# Altium schematic sheet KDT849SPDT-003-射频开关.SchDoc
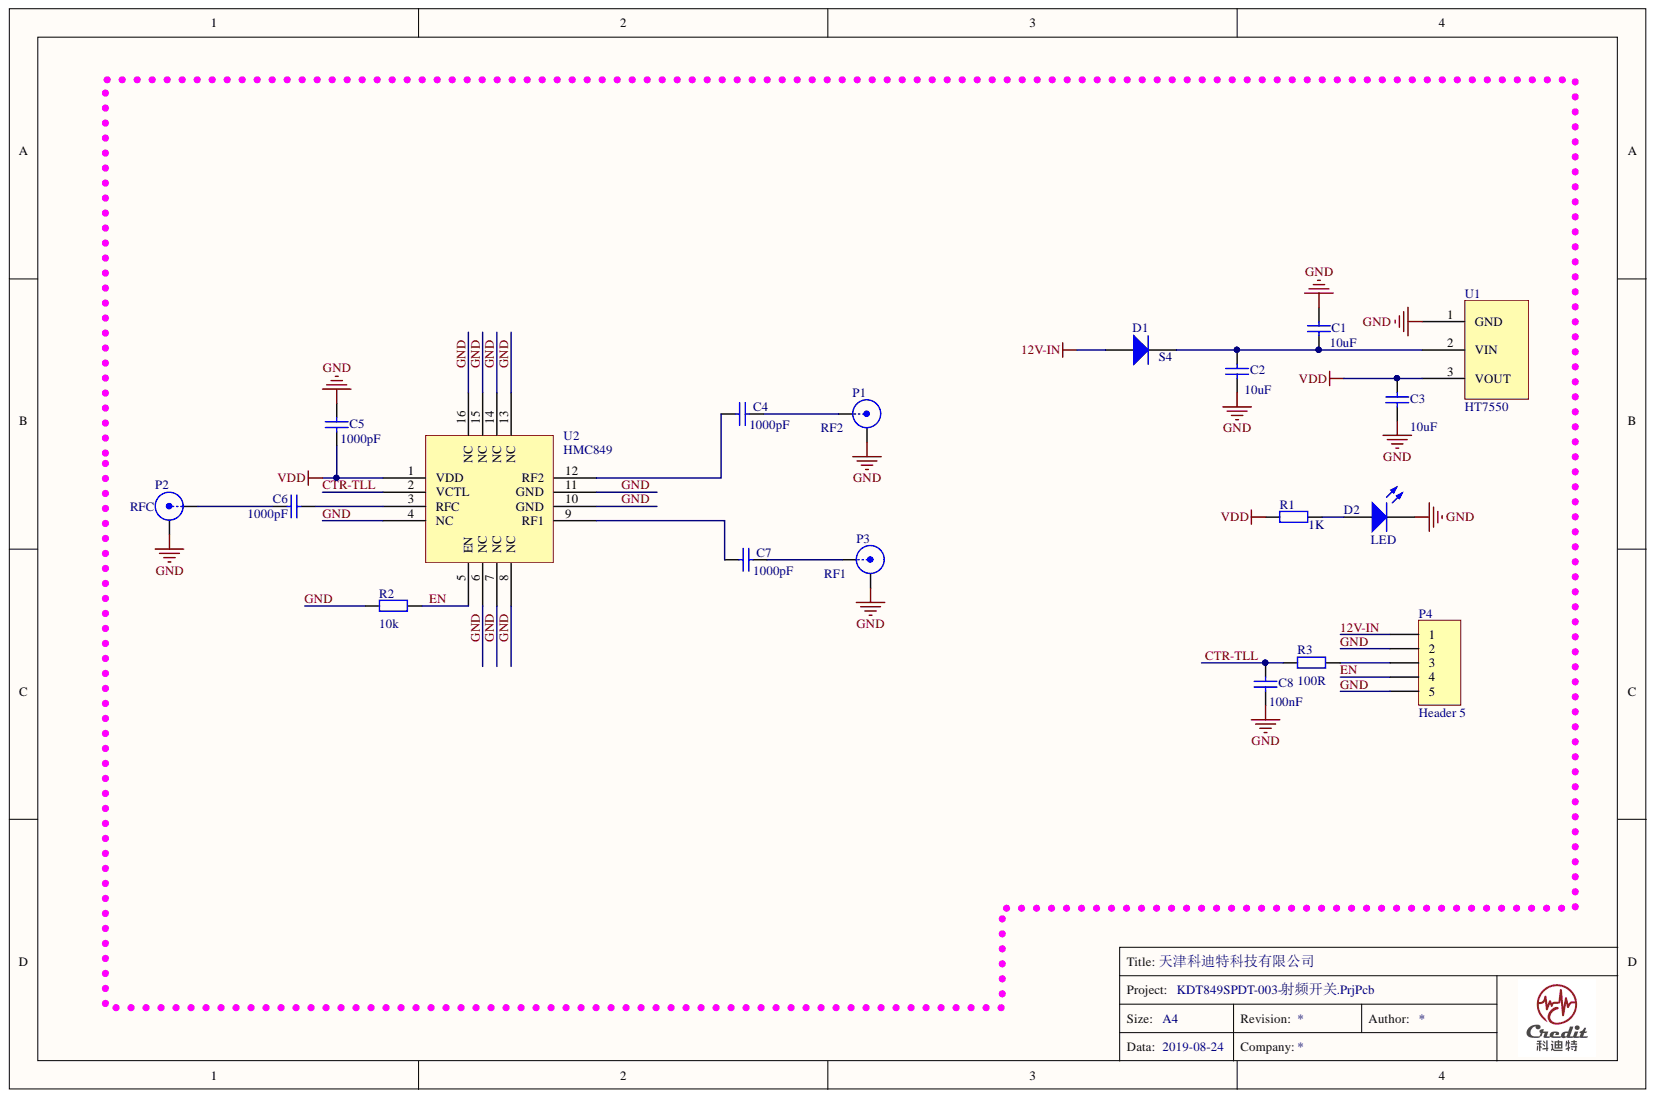
[diagram p0: KDT849SPDT-003-射频开关.SchDoc]

## Parts
C1  value 10uF  [C1206]
C2  value 10uF  [C0805]
C3  value 10uF  [C0805]
C4  value 1000pF  [C0603]
C5  value 1000pF  [C0603]
C6  value 1000pF  [C0603]
C7  value 1000pF  [C0603]
C8  value 100nF  [C0603]
D1  S4  [SOD123]
D2  LED  [LED0805R]
P1  RF2  [SM]
P2  RFC  [SM]
P3  RF1  [SM]
P4  Header 5  [XH2.54-LI-5P]
R1  value 1K  [R0603]
R2  value 10k  [R0603]
R3  value 100R  [R0603]
U1  HT7550  [SOT-89]
U2  HMC849  [QFN16 4x4_N]

## Nets
VDD: C3.1 C5.1 R1.1 U1.3 U2.1(VDD)
12V-IN: D1.1 P4.1
CTR-TLL: C8.1 R3.2 U2.2(VCTL)
EN: P4.4 R2.1 U2.5(EN)
GND: C1.2 C2.2 C3.2 C5.2 C8.2 D2.2 P1.2 P2.2 P3.2 P4.2 P4.5 R2.2 U1.1 U2.4(NC) U2.6(NC) U2.7(NC) U2.8(NC) U2.10(GND) U2.11(GND) U2.13(NC) U2.14(NC) U2.15(NC) U2.16(NC)
NetC1_1: C1.1 C2.1 D1.2 U1.2
NetC4_1: C4.1 P1.1
NetC4_2: C4.2 U2.12(RF2)
NetC6_1: C6.1 P2.1
NetC6_2: C6.2 U2.3(RFC)
NetC7_1: C7.1 P3.1
NetC7_2: C7.2 U2.9(RF1)
NetD2_1: D2.1 R1.2
NetP4_3: P4.3 R3.1

## Library data of the parts
C1-C8 = Cap: Capacitor; Component Kind: Standard; LatestRevisionDate: 17-Jul-2002; LatestRevisionNote: Re-released for DXP Platform.; Library Name: Miscellaneous Devices.IntLib; PackageDescription: Radial Cap, Thru-Hole; 2 Leads; 0.3 in Pin Spacing; PackageReference: RAD-0.3; Published: 8-Jun-2000; Signal Integrity: Cap; Simulation: CAP; Publisher: Altium Limited
D1 = DIODE: High Conductance Fast Diode; Code_JEDEC: DO-204-AH; Component Kind: Standard; ComponentLink1Description: Manufacturer Link; ComponentLink1URL: http://www.fairchildsemi.com/; ComponentLink2Description: Datasheet; ComponentLink2URL: http://www.fairchildsemi.com/ds/1N%2F1N4448.pdf; DatasheetVersion: 1997; LatestRevisionDate: 17-Jul-2002; LatestRevisionNote: Re-released for DXP Platform.; Library Name: Miscellaneous Devices.SchLib; PackageDescription: Diode, Axial; Body 3.8 x 1.9 mm (LxDia. max), Lead Dia. 0.53 mm (max); PackageReference: DO-35; Published: 8-Jun-2000; Publisher: Altium Limited
P1-P3 = BNC: BNC Elbow Connector; Component Kind: Standard; LatestRevisionDate: 17-Jul-2002; LatestRevisionNote: Re-released for DXP Platform.; Library Name: Miscellaneous Devices.SchLib; Signal Integrity: Connector; Publisher: Altium Limited
P4 = Header 5: Header, 5-Pin; Component Kind: Standard; LatestRevisionDate: 17-Jul-2002; LatestRevisionNote: Re-released for DXP Platform.; Signal Integrity: Connector; Library Name: Xiaowei.SchLib; Publisher: Altium Limited
R1-R3 = Res2: Resistor; Component Kind: Standard; LatestRevisionDate: 17-Jul-2002; LatestRevisionNote: Re-released for DXP Platform.; Library Name: Miscellaneous Devices.IntLib; PackageDescription: Axial Device, Thru-Hole; 2 Leads; 0.4 in Pin Spacing; PackageReference: AXIAL-0.4; Published: 8-Jun-2000; Signal Integrity: Res; Simulation: RESISTOR; Publisher: Altium Limited
U1 = HT7550-5V: Component Kind: Standard; Library Name: Xiaowei.SchLib
D2 = LED: Component Kind: Standard; LatestRevisionDate: 08-Jul-2005; LatestRevisionNote: Mech Layer 1 removed.; PackageDescription: LED; 2 Leads; PackageReference: LED-0; Published: 8-Jun-2000; Library Name: Xiaowei.SchLib; Publisher: Altium Limited
U2 = HMC849: Component Kind: Standard; Library Name: Xiaowei.SchLib
